# Altium schematic sheet 09-RF_SUBG.SchDoc(09-RF_SUBG)
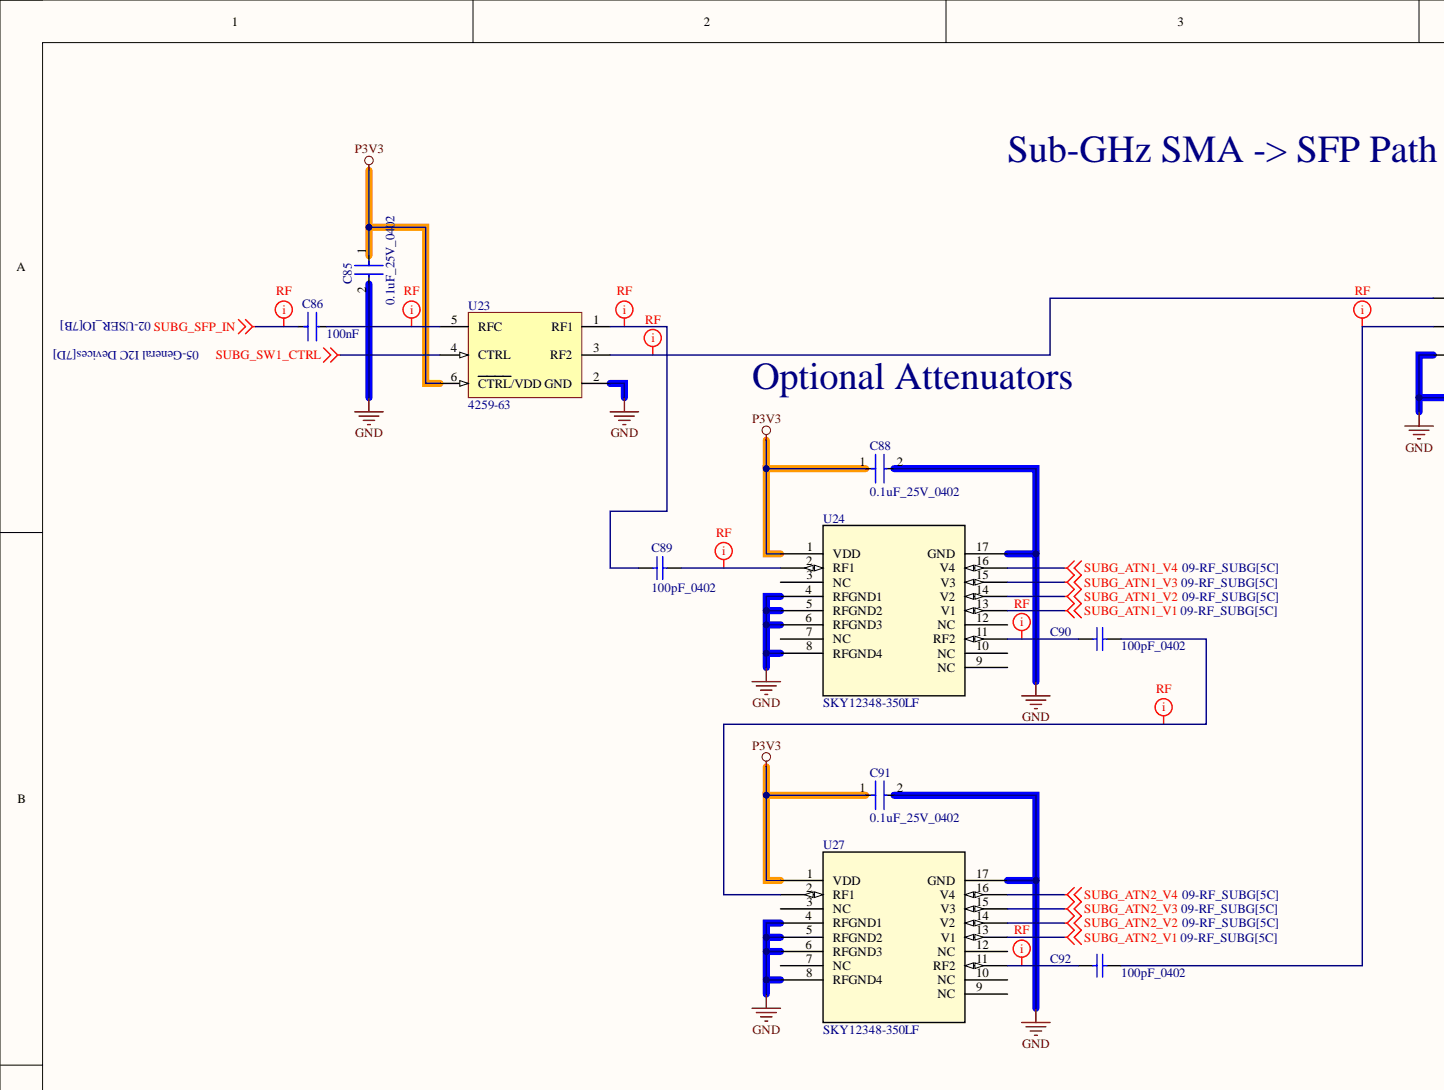
[diagram p0: 09-RF_SUBG.SchDoc, top left]
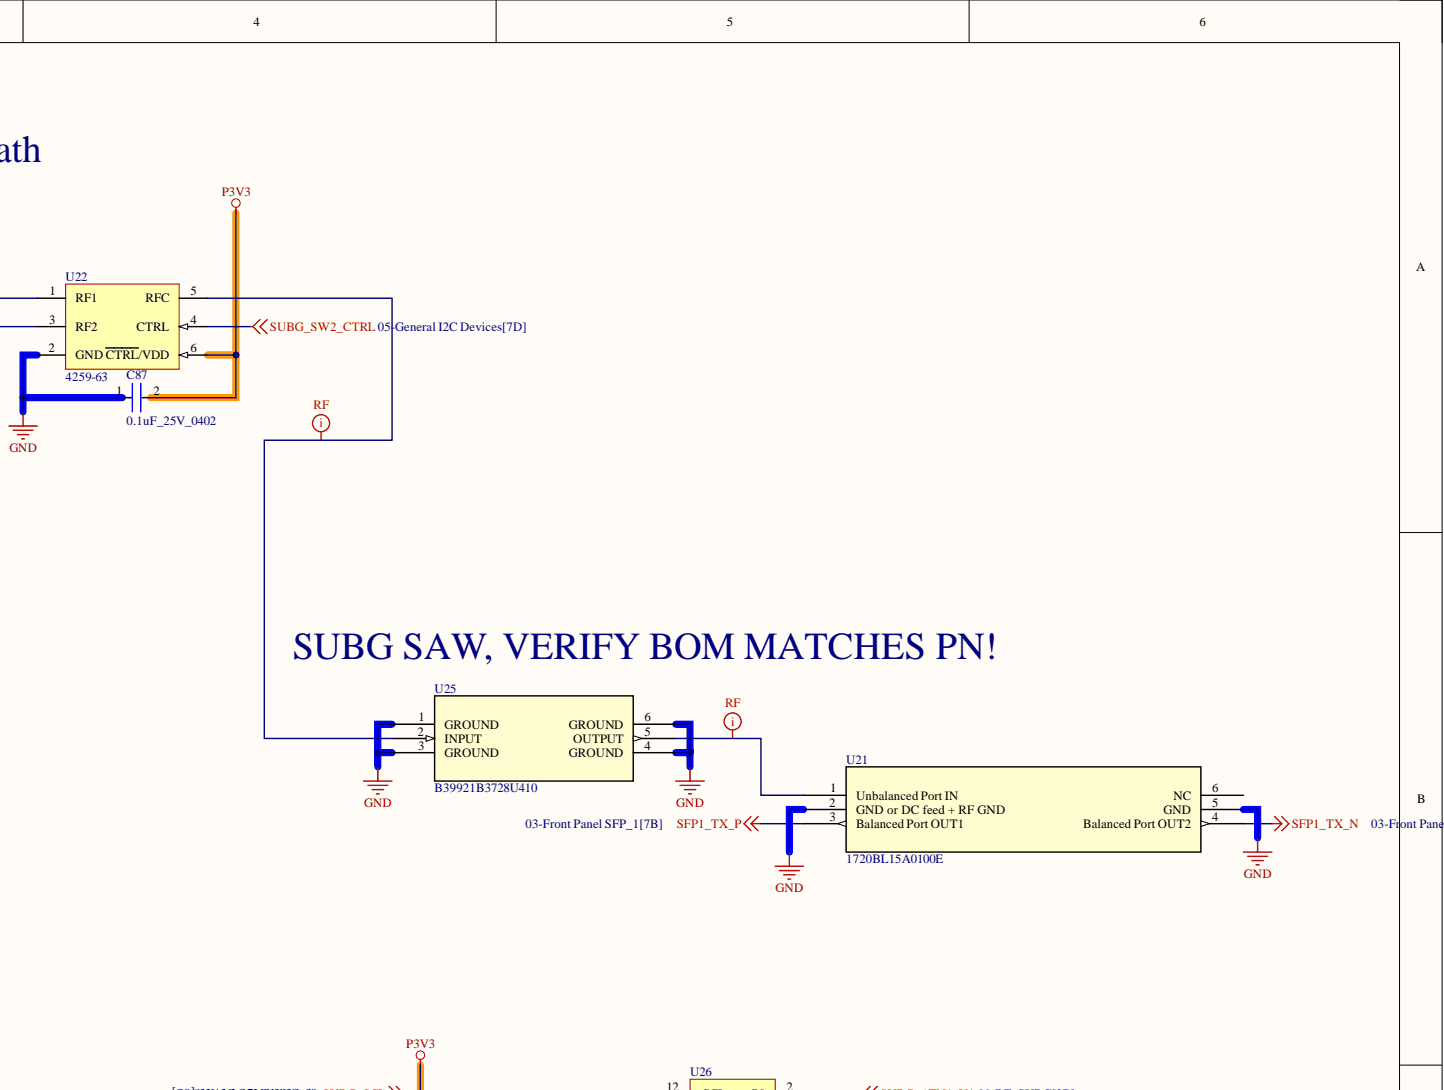
[diagram p1: 09-RF_SUBG.SchDoc, top right]
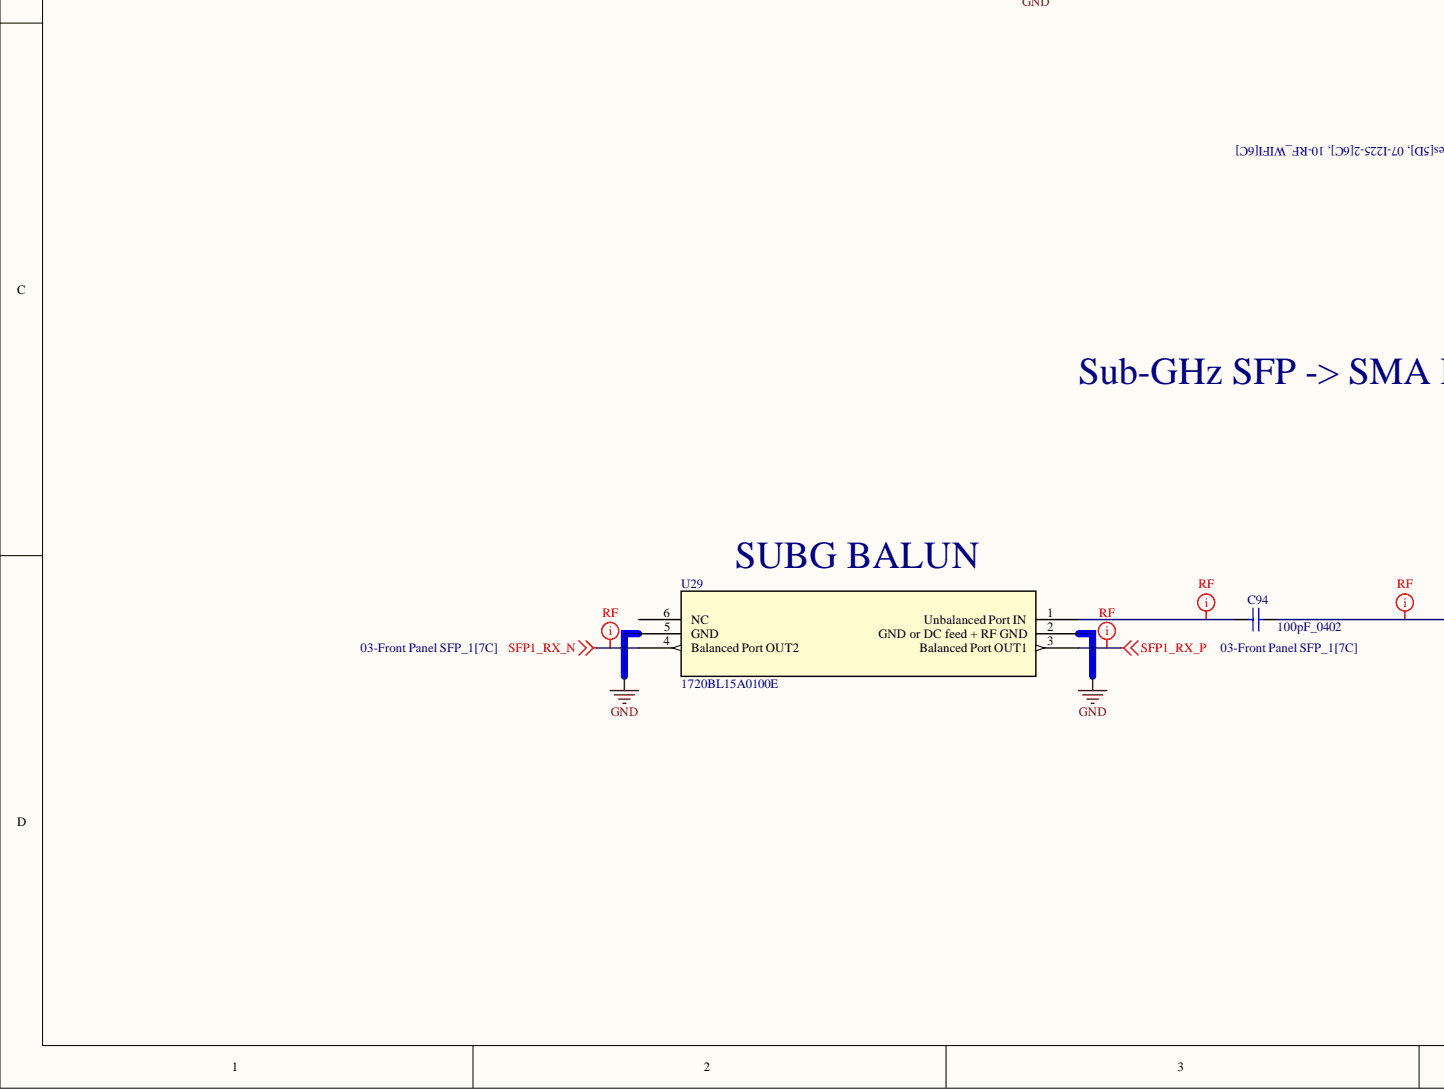
[diagram p2: 09-RF_SUBG.SchDoc, bottom left]
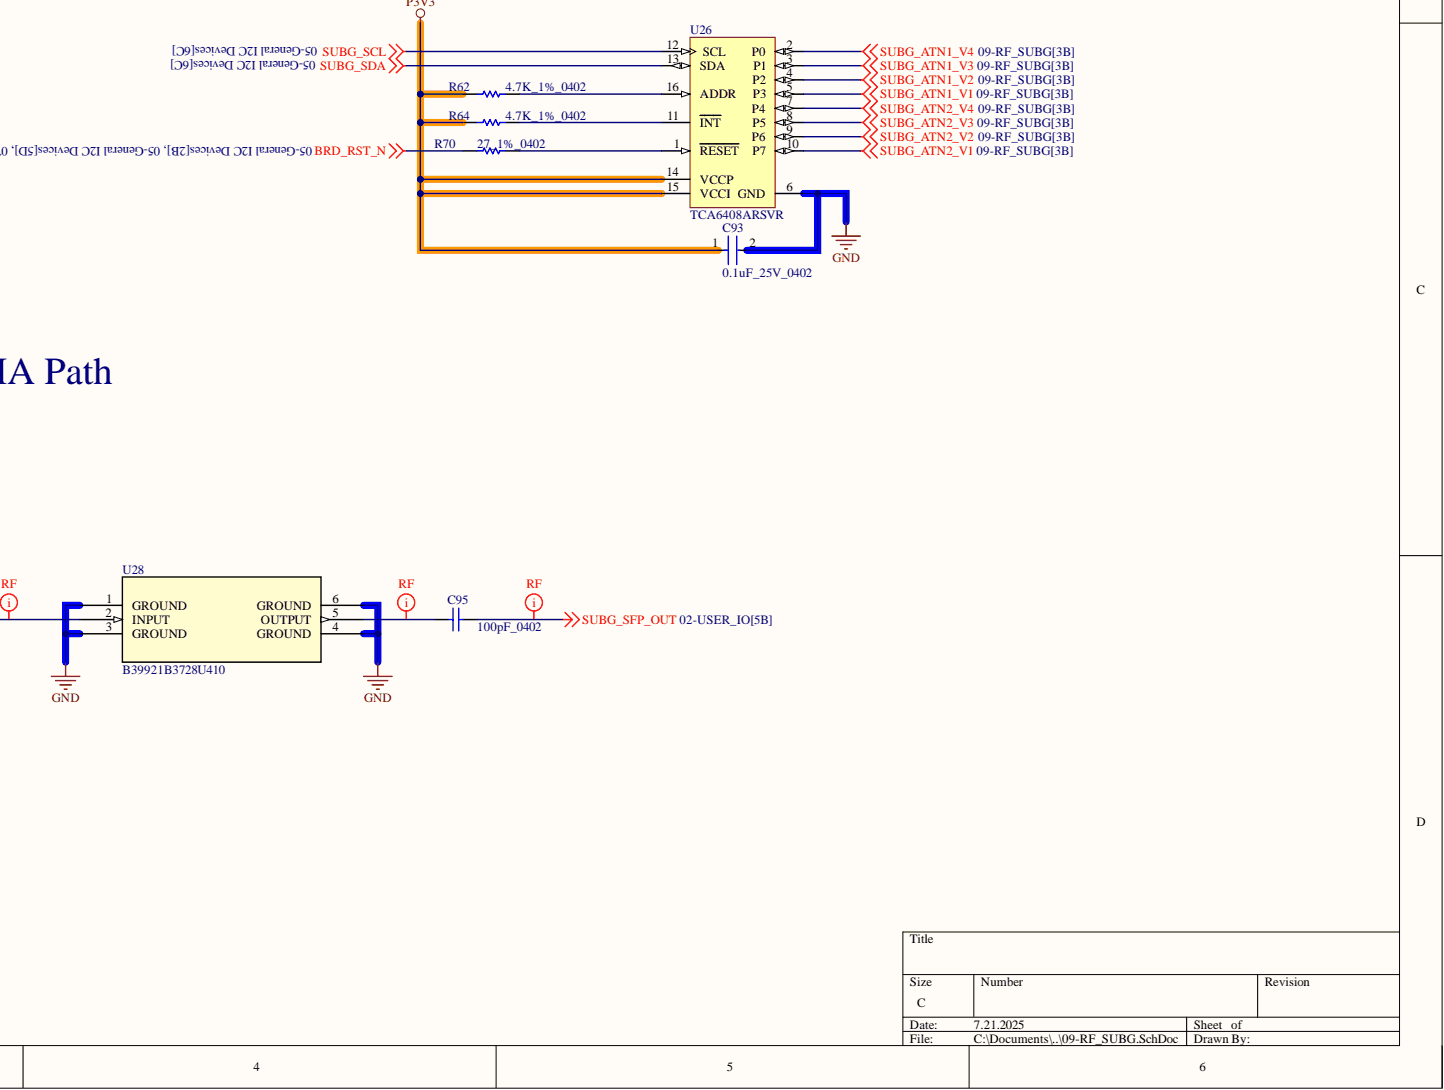
[diagram p3: 09-RF_SUBG.SchDoc, bottom right]

## Parts
C85  0.1uF_25V_0402  [FP-0402-L_1_0_1-W_0_5_0_1-IPC_C]
C86  100nF  [FP-0402-L_1_0_0_05-W_0_5-IPC_B]
C87  0.1uF_25V_0402  [FP-0402-L_1_0_1-W_0_5_0_1-IPC_C]
C88  0.1uF_25V_0402  [FP-0402-L_1_0_1-W_0_5_0_1-IPC_C]
C89  100pF_0402  [CAPC1005X55X25ML05T10]
C90  100pF_0402  [CAPC1005X55X25ML05T10]
C91  0.1uF_25V_0402  [FP-0402-L_1_0_1-W_0_5_0_1-IPC_C]
C92  100pF_0402  [CAPC1005X55X25ML05T10]
C93  0.1uF_25V_0402  [FP-0402-L_1_0_1-W_0_5_0_1-IPC_C]
C94  100pF_0402  [CAPC1005X55X25ML05T10]
C95  100pF_0402  [CAPC1005X55X25ML05T10]
R62  4.7K_1%_0402  [RESC1005X40X25NL10T10]
R64  4.7K_1%_0402  [RESC1005X40X25NL10T10]
R70  27_1%_0402  [RESC1005X40X25NL05T05]
U21  1720BL15A0100E  [SMT_15B0050E_JOT]
U22  4259-63  [PERE-SC-70-6_M]
U23  4259-63  [PERE-SC-70-6_M]
U24  SKY12348-350LF  [SKY12348-350LF_SKY]
U25  B39921B3728U410  [B39162B3913U410_QUA]
U26  TCA6408ARSVR  [RSV0016A_V]
U27  SKY12348-350LF  [SKY12348-350LF_SKY]
U28  B39921B3728U410  [B39162B3913U410_QUA]
U29  1720BL15A0100E  [SMT_15B0050E_JOT]

## Nets
BRD_RST_N: R70.1
GND: C85.2 C87.1 C88.2 C91.2 C93.2 U21.2(GND_or_DC_feed_+_RF_GND) U21.5(GND) U22.2(GND) U23.2(GND) U24.4(RFGND1) U24.5(RFGND2) U24.6(RFGND3) U24.8(RFGND4) U24.17(GND) U25.1(GROUND) U25.3(GROUND) U25.4(GROUND) U25.6(GROUND) U26.6(GND) U27.4(RFGND1) U27.5(RFGND2) U27.6(RFGND3) U27.8(RFGND4) U27.17(GND) U28.1(GROUND) U28.3(GROUND) U28.4(GROUND) U28.6(GROUND) U29.2(GND_or_DC_feed_+_RF_GND) U29.5(GND)
P3V3: C85.1 C87.2 C88.1 C91.1 C93.1 R62.2 R64.2 U22.6(CTRL/VDD) U23.6(CTRL/VDD) U24.1(VDD) U26.14(VCCP) U26.15(VCCI) U27.1(VDD)
SFP1_RX_N: U29.4(Balanced_Port_OUT2)
SFP1_RX_P: U29.3(Balanced_Port_OUT1)
SFP1_TX_N: U21.4(Balanced_Port_OUT2)
SFP1_TX_P: U21.3(Balanced_Port_OUT1)
SUBG_ATN1_V1: U24.13(V1) U26.5(P3)
SUBG_ATN1_V2: U24.14(V2) U26.4(P2)
SUBG_ATN1_V3: U24.15(V3) U26.3(P1)
SUBG_ATN1_V4: U24.16(V4) U26.2(P0)
SUBG_ATN2_V1: U26.10(P7) U27.13(V1)
SUBG_ATN2_V2: U26.9(P6) U27.14(V2)
SUBG_ATN2_V3: U26.8(P5) U27.15(V3)
SUBG_ATN2_V4: U26.7(P4) U27.16(V4)
SUBG_SCL: U26.12(SCL)
SUBG_SDA: U26.13(SDA)
SUBG_SFP_IN: C86.1
SUBG_SFP_OUT: C95.2
SUBG_SW1_CTRL: U23.4(CTRL)
SUBG_SW2_CTRL: U22.4(CTRL)
NetC86_2: C86.2 U23.5(RFC)
NetC89_1: C89.1 U23.1(RF1)
NetC89_2: C89.2 U24.2(RF1)
NetC90_1: C90.1 U24.11(RF2)
NetC90_2: C90.2 U27.2(RF1)
NetC92_1: C92.1 U27.11(RF2)
NetC92_2: C92.2 U22.3(RF2)
NetC94_1: C94.1 U29.1(Unbalanced_Port_IN)
NetC94_2: C94.2 U28.2(INPUT)
NetC95_1: C95.1 U28.5(OUTPUT)
NetR62_1: R62.1 U26.16(ADDR)
NetR64_1: R64.1 U26.11(INT)
NetR70_2: R70.2 U26.1(RESET)
NetU21_1: U21.1(Unbalanced_Port_IN) U25.5(OUTPUT)
NetU22_1: U22.1(RF1) U23.3(RF2)
NetU22_5: U22.5(RFC) U25.2(INPUT)

Not connected: U21 6(NC); U24 3(NC) 7(NC) 9(NC) 10(NC) 12(NC); U27 3(NC) 7(NC) 9(NC) 10(NC) 12(NC); U29 6(NC)

## Library data of the parts
C85 C87 C88 C91 C93 = CMP-2008-02519-2: General Purpose Ceramic Capacitor, 0402, 100nF, 10%, X7R, 15%, 25V; Capacitance: 100nF; Case Code (Imperial): 0402; Case Code (Metric): 1005; Case/Package: 0402; Component Kind: Standard; Construction: Flat; Depth: 0.5mm; Dielectric: X7R; Lead Free: Lead Free; Length: 1mm; Library Name: Altium Content Vault; Max Operating Temperature: 125°C; Min Operating Temperature: -55°C; Mount: Surface Mount; Number of Pins: 2; Package Quantity: 1; Packaging: Tape and Reel; Radiation Hardening: No; REACH SVHC: No SVHC; RoHS Compliant: Yes; Series: -; Termination: SMD/SMT; Thickness: 0.022inch; Tolerance: 10%; Voltage: 25V; Voltage Rating: 25V; Voltage Rating (DC): 25V; Width: 0.02inch
C86 = CMP-14477-000330-2: None; Dielectric: X5R; Tolerance: 10%; Voltage Rating: 50V; Capacitance: 100nF; Case Code (Imperial): 0402; Case Code (Metric): 1005; Case/Package: 0402; Component Kind: Standard; Halogen Free: Halogen Free; Height: 0.5mm; Length: 0.039inch; Library Name: Altium Content Vault; Max Operating Temperature: 85°C; Min Operating Temperature: -55°C; Mount: Surface Mount; Package Quantity: 10000; Packaging: Tape and Reel; RoHS Compliant: Yes; Simulation: UMK105BJ104_V-F; Termination: SMD/SMT; Thickness: 0.022inch; Voltage Rating (DC): 50V; Width: 0.02inch
C89 C90 C92 C94 C95 = CMP-2008-03915-1: Manufacturer: Murata; Part Number: GRM1555C1H101JA01D; Component Kind: Standard; Library Name: Altium Content Vault
R62 R64 = CMP-2002-05217-1: Manufacturer: Bourns; Part Number: CR0402-FX-4701GLF; Component Kind: Standard; Library Name: Altium Content Vault
R70 = CMP-2002-08434-1: Manufacturer: Vishay Dale; Part Number: CRCW040227R0FKED; Component Kind: Standard; Library Name: Altium Content Vault
U21 U29 = 1720BL15A0100E: No Description Available; Mfr_Name: Johanson Technology; Component Kind: Standard; Library Name: 1720BL15A0100E.SchLib; RefDes: RefDes; Type: Type
U22 U23 = CMP-2000-05298-1: IC RF Switch SPDT, 50 Ohm, 6-Pin SC70, RoHS, Tape and Reel; Manufacturer: Peregrine Semiconductor; Frequency - Lower: 10MHz; Frequency - Upper: 3GHz; IIP3: 55dBm(typ); Impedance: 50Ohm; Insertion Loss @ Frequency: 0.5dB@2GHz; Isolation @ Frequency: 20dB@2GHz(typ); Online Catalog: PE4259; Other Names: 1046-1011-24259-63-ND425963PE4259PE4259SCBC-ZPE4259SCBC-Z-ND; P1dB: 29dBm(typ)IP1dB; RF Type: GeneralPurpose; Topology: Reflective; Voltage - Supply: 1.8V~3.3V; Category: RF/IFandRFID; Circuit: SPDT; Component Kind: Standard; Datasheet URL: https://datasheet.ciiva.com/15100/getdatasheetpartid-685660-15100501.pdf; Datasheet Version: Jan-11; Family: RFSwitches; Features: Single/DualLineControl; Library Name: Altium Content Vault; Manufacturer URL: http://www.psemi.com/; Operating Temperature: -40degC~85degC; Package / Case: 6-TSSOP,SC-88,SOT-363; Package Description: 6-Pin Small Outline Transistor, Body 2.05 x 1.25 mm, Pitch 0.65 mm; Package Reference: SC-70-6; Packaging: Tape&Reel(TR); Series: UltraCMOS; Standard Package: 3,000; Supplier Device Package: SC-70-6
U24 U27 = SKY12348-350LF: No Description Available; Mfr_Name: Skyworks; Component Kind: Standard; Library Name: SKY12348-350LF.SchLib; RefDes: RefDes; Type: Type
U25 U28 = B39162B3913U410: No Description Available; Mfr_Name: Qualcomm; Component Kind: Standard; Library Name: B39162B3913U410.SchLib; RefDes: RefDes; Type: Type
U26 = CMP-0314-00021-2: Low-Voltage 8-Bit I2C and SMBus I/O Expander, 1.65 to 5.5 V, -40 to 85 degC, 16-pin UQFN (RSV), Green (RoHS & no Sb/Br); Manufacturer: Texas Instruments; 5V Tolerant I/O: Yes; # of I/Os: 8; fSCLK(Max)(MHz): 400; I2C Address: 0100 00x; SubFamily: I2C IO Expander; VCC(Max)(V): 5.5; VCC(Min)(V): 1.65; Code_JEDEC: MO-288*; Component Kind: Standard; ComponentLink1Description: Datasheet; ComponentLink1URL: http://www.ti.com/general/docs/lit/getliterature.tsp?genericPartNumber=TCA6408A&fileType=pdf; ComponentLink2Description: Package Specification; ComponentLink2URL: http://www.ti.com/litv/pdf/mpqf115g; Configuration Registers: Yes; DatasheetVersion: SCPS192C; Eco-Plan: Green (RoHS & no Sb/Br); Interrupt Output: Yes; Library Name: Altium Content Vault; Low Power: Yes; Max Frequency(kHz): 400; Mounting Technology: Surface Mount; No. of Outputs: 8; PackageDescription: 16-Leads Plastic Quad Flatpack No-Lead, 2.6 x 1.8 x 0.5 mm Body, 0.4 mm Pitch; PackageReference: RSV0016A; PackageVersion: revD, Dec-2011; PartNumber: TCA6408ARSVR; Push-Pull I/O Type: Yes; Reset Input: Yes; Vcc range(V): 1.65 to 5.5
